# Altium schematic sheet Sheet1.SchDoc
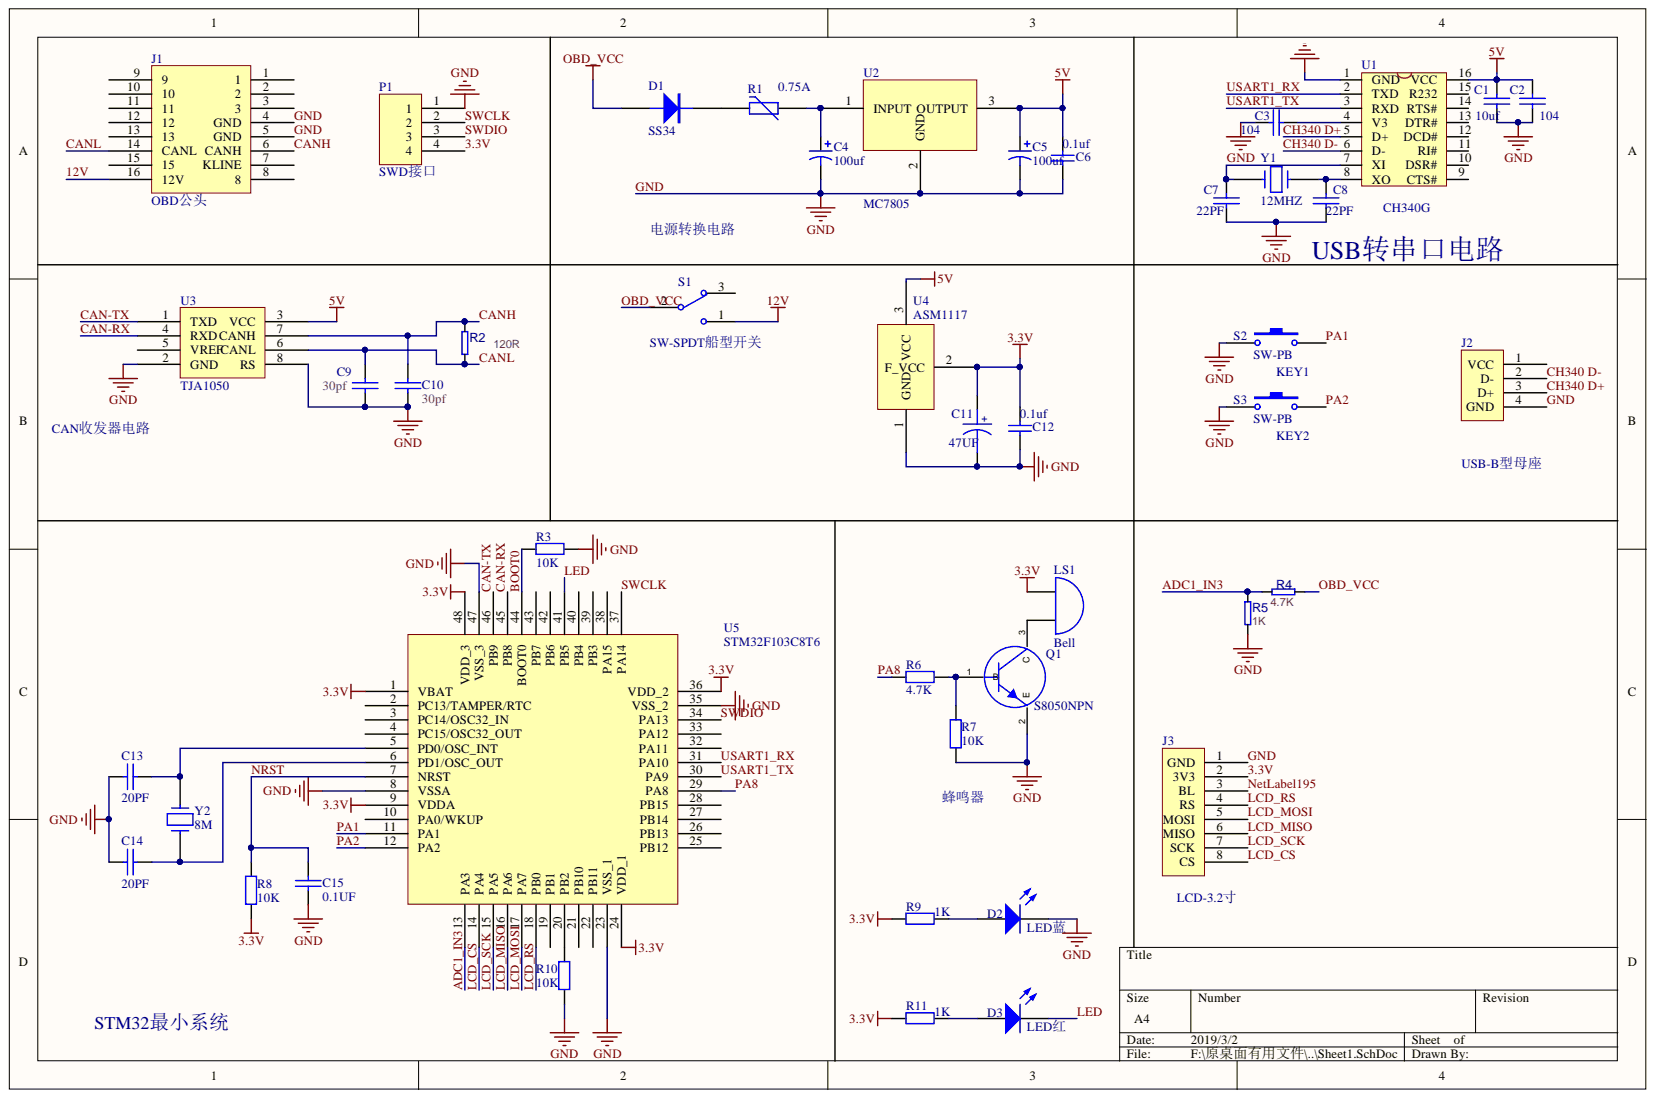
[diagram p0: Sheet1.SchDoc]

## Parts
C1  10uf  [6-0805_N]
C2  104  [6-0805_N]
C3  104  [6-0805_N]
C4  100uf  [贴片铝电解电容6.6*7.7]
C5  100uf  [贴片铝电解电容6.6*7.7]
C6  0.1uf  [6-0805_N]
C7  22PF  [6-0805_N]
C8  22PF  [6-0805_N]
C9  30pf  [6-0805_N]
C10  30pf  [6-0805_N]
C11  47uf  [6-0805_N]
C12  0.1uf  [6-0805_N]
C13  value 20PF  [6-0805_N]  (hidden comment: 20p)
C14  value 20PF  [6-0805_N]  (hidden comment: 20p)
C15  0.1uf  [6-0805_N]
D1  SS34  [MLL34]
D2  LED0  [0805LED灯]  (value not drawn)
D3  LED0  [0805LED灯]  (value not drawn)
J1  OBD公头  [OBD公头普通]
J2  USB-B型母座  [USB-B母座]  (value not drawn)
J3  LCD-3.2寸  [LCD3.2寸]
LS1  Bell  [蜂鸣器]
P1  SWD接口  [2.54排针-4P]
Q1  S8050NPN  [S8050-J3Y]
R1  value 0.75A  [1812]  (hidden comment: PPTC_20A)
R2  120R  [6-0805_N]
R3  10K  [6-0805_N]
R4  4.7K  [6-0805_N]
R5  1K  [6-0805_N]
R6  value 4.7K  [6-0805_N]  (hidden comment: 10K)
R7  10K  [6-0805_N]
R8  10K  [6-0805_N]
R9  1K  [6-0805_N]
R10  10K  [6-0805_N]
R11  1K  [6-0805_N]
S1  SW-SPDT船型开关  [船型开关KCD11-2脚]
S2  SW-PB  [4脚轻触按键]
S3  SW-PB  [4脚轻触按键]
U1  CH340G  [LC-SOP-16_150mil]
U2  MC7805  [TO-252-2(DPAK)]
U3  TJA1050  [485]
U4  ASM1117  [ASM1117]
U5  STM32F103C8T6  [LQFP48]
Y1  12MHZ  [3225晶振]
Y2  value 8M  [3225晶振]  (hidden comment: 8MHZ)

## Nets
5V: C1.1 C2.1 C5.1 C6.2 U1.16(VCC) U2.3 U3.3(VCC) U4.3
3.3V: C11.1 C12.2 J3.2(3V3) LS1.1 P1.4 R8.2 R9.2 R11.2 U4.2 U5.1(VBAT) U5.9(VDDA) U5.24(VDD_1) U5.36(VDD_2) U5.48(VDD_3)
12V: J1.16(12V) S1.1
ADC1_IN3: R4.2 R5.1 U5.13(PA3)
BOOT0: R3.1 U5.44(BOOT0)
CAN-RX: U3.4(RXD) U5.45(PB8)
CAN-TX: U3.1(TXD) U5.46(PB9)
CANH: C10.1 J1.6(CANH) R2.2 U3.7(CANH)
CANL: C9.1 J1.14(CANL) R2.1 U3.6(CANL)
CH340 D+: J2.3(D+) U1.5(D+)
CH340 D-: J2.2(D-) U1.6(D-)
GND: C1.2 C2.2 C3.1 C4.2 C5.2 C6.1 C7.2 C8.2 C9.2 C10.2 C11.2 C12.1 C13.2 C14.2 C15.1 D2.2 J1.4(GND) J1.5(GND) J2.4(GND) J3.1(GND) P1.1 Q1.2 R3.2 R5.2 R7.2 R10.2 S2.1 S3.1 U1.1(GND) U2.2 U3.2(GND) U3.8(RS) U4.1 U5.8(VSSA) U5.23(VSS_1) U5.35(VSS_2) U5.47(VSS_3)
LCD_CS: J3.8(CS) U5.14(PA4)
LCD_MISO: J3.6(MISO) U5.16(PA6)
LCD_MOSI: J3.5(MOSI) U5.17(PA7)
LCD_RS: J3.4(RS) U5.18(PB0)
LCD_SCK: J3.7(SCK) U5.15(PA5)
LED: D3.2 U5.41(PB5)
NETLABEL195: J3.3(BL)
NRST: C15.2 R8.1 U5.7(NRST)
OBD_VCC: D1.1 R4.1 S1.2
PA1: S2.2 U5.11(PA1)
PA2: S3.2 U5.12(PA2)
PA8: R6.1 U5.29(PA8)
SWCLK: P1.2 U5.37(PA14)
SWDIO: P1.3 U5.34(PA13)
USART1_RX: U1.2(TXD) U5.31(PA10)
USART1_TX: U1.3(RXD) U5.30(PA9)
NetC3_2: C3.2 U1.4(V3)
NetC4_1: C4.1 R1.1 U2.1
NetC7_1: C7.1 U1.7(XI) Y1.2
NetC8_1: C8.1 U1.8(XO) Y1.1
NetC13_1: C13.1 U5.5(PD0/OSC_INT) Y2.2
NetC14_1: C14.1 U5.6(PD1/OSC_OUT) Y2.1
NetD1_2: D1.2 R1.2
NetD2_1: D2.1 R9.1
NetD3_1: D3.1 R11.1
NetLS1_2: LS1.2 Q1.3
NetQ1_1: Q1.1 R6.2 R7.1
NetR10_1: R10.1 U5.20(PB2)

Not connected: J1 1 2 3 7(KLINE) 8 9 10 11 12 13 15; J2 1(VCC); S1 3; U1 9(CTS#) 10(DSR#) 11(RI#) 12(DCD#) 13(DTR#) 14(RTS#) 15(R232); U3 5(VREF); U5 2(PC13/TAMPER/RTC) 3(PC14/OSC32_IN) 4(PC15/OSC32_OUT) 10(PA0/WKUP) 19(PB1) 21(PB10) 22(PB11) 25(PB12) 26(PB13) 27(PB14) 28(PB15) 32(PA11) 33(PA12) 38(PA15) 39(PB3) 40(PB4) 42(PB6) 43(PB7)

## Library data of the parts
C1-C3 C7 C8 C13-C15 = CAP: Capacitor; Component Kind: Standard
  C1: Value: 10uf
  C2 C3: Value: 104
  C7 C8: Value: 22PF
  C15: Value: 0.1UF
C4 C5 = Cap Pol1: Polarized Capacitor (Radial); Component Kind: Standard; LatestRevisionDate: 17-Jul-2002; LatestRevisionNote: Re-released for DXP Platform.; Library Name: Miscellaneous Devices.IntLib; PackageDescription: Polarized Capacitor (Radial); 2 Leads; PackageReference: RB7.6-15; Published: 8-Jun-2000; Publisher: Altium Limited
C6 C12 = Cap: Capacitor; Component Kind: Standard; LatestRevisionDate: 17-Jul-2002; LatestRevisionNote: Re-released for DXP Platform.; Library Name: Miscellaneous Devices.IntLib; PackageDescription: Radial Cap, Thru-Hole; 2 Leads; 0.3 in Pin Spacing; PackageReference: RAD-0.3; Published: 8-Jun-2000; Publisher: Altium Limited
C9 C10 = CAP: 电容; Component Kind: Standard
C11 = CAPACITOR POL: Capacitor; Component Kind: Standard; Value: 47UF
D1 = DIODE: Component Kind: Standard; Description: Diode; Value: SS34
D2 = LED0: Typical INFRARED GaAs LED; Value: LED蓝; Component Kind: Standard; LatestRevisionDate: 08-Jul-2005; LatestRevisionNote: Mech Layer 1 removed.; Library Name: Miscellaneous Devices.IntLib; PackageDescription: LED; 2 Leads; PackageReference: LED-0; Published: 8-Jun-2000; Publisher: Altium Limited
D3 = LED0: Typical INFRARED GaAs LED; Value: LED红; Component Kind: Standard; LatestRevisionDate: 08-Jul-2005; LatestRevisionNote: Mech Layer 1 removed.; Library Name: Miscellaneous Devices.IntLib; PackageDescription: LED; 2 Leads; PackageReference: LED-0; Published: 8-Jun-2000; Publisher: Altium Limited
J1 = OBD公头-ELM327: Component Kind: Standard; Library Name: Schlib1_Q.SchLib
LS1 = Bell: Electrical Bell; Component Kind: Standard; LatestRevisionDate: 17-Jul-2002; LatestRevisionNote: Re-released for DXP Platform.; Library Name: Miscellaneous Devices.IntLib; PackageReference: PIN2; Published: 24-Mar-1999; Publisher: Altium Limited
P1 = 4P接口端子: Component Kind: Standard; Library Name: 武汉蓝牙版.SchLib
R1 = VARISTOR: Component Kind: Standard
R2 R4 R5 = RES2: R?; Component Kind: Standard
R3 R6-R11 = Res2: Resistor; Component Kind: Standard; LatestRevisionDate: 17-Jul-2002; LatestRevisionNote: Re-released for DXP Platform.; Library Name: Miscellaneous Devices.IntLib; PackageDescription: Axial Device, Thru-Hole; 2 Leads; 0.4 in Pin Spacing; PackageReference: AXIAL-0.4; Published: 8-Jun-2000; Publisher: Altium Limited
  R3 R7 R8 R10: Value: 10K
S1 = SW-SPDT: SPDT Subminiature Toggle Switch, Right Angle Mounting, Vertical Actuation; Component Kind: Standard; ComponentLink1Description: Manufacturer Link; ComponentLink1URL: http://www.apem.com; DatasheetVersion: 05-Dec-2000; LatestRevisionDate: 17-Dec-2003; LatestRevisionNote: Schematic revised, PCB Footprint replaced.; Library Name: Miscellaneous Devices.IntLib; PackageDescription: Switch; 4 Leads; PackageReference: TL36WW15050; Published: 24-Mar-1999; Publisher: Altium Limited
S2 S3 = SW-PB: Switch; Component Kind: Standard; LatestRevisionDate: 17-Jul-2002; LatestRevisionNote: Re-released for DXP Platform.; Library Name: Miscellaneous Devices.IntLib; PackageDescription: Switch; 2 Leads; PackageReference: SPST-2; Published: 24-Mar-1999; Publisher: Altium Limited
U1 = CH340G: USB2UART; Component Kind: Standard; Library Name: MiniSTM32 V3.0封装库.IntLib
Y1 Y2 = CRYSTAL: Component Kind: Standard; Description: Crystal
  Y1: Value: 12MHZ
J2 = USB-B型母座: Component Kind: Standard; Library Name: Schlib1_Q.SchLib
J3 = LCD-3.2寸: Component Kind: Standard; Library Name: Schlib1_Q.SchLib
Q1 = S8050NPN: Component Kind: Standard; Library Name: Schlib1_Q.SchLib
U2 = MC7805: Component Kind: Standard; Library Name: 武汉蓝牙版.SchLib
U3 = TJA1050: Component Kind: Standard; Library Name: 武汉蓝牙版.SchLib
U4 = ASM1117: Component Kind: Standard; Value: ASM1117
U5 = STM32F103C8T6: Component Kind: Standard; Library Name: SchlibQ.SchLib
